# Altium schematic sheet PFM_SDCard.SchDoc(PFM_SDCard)
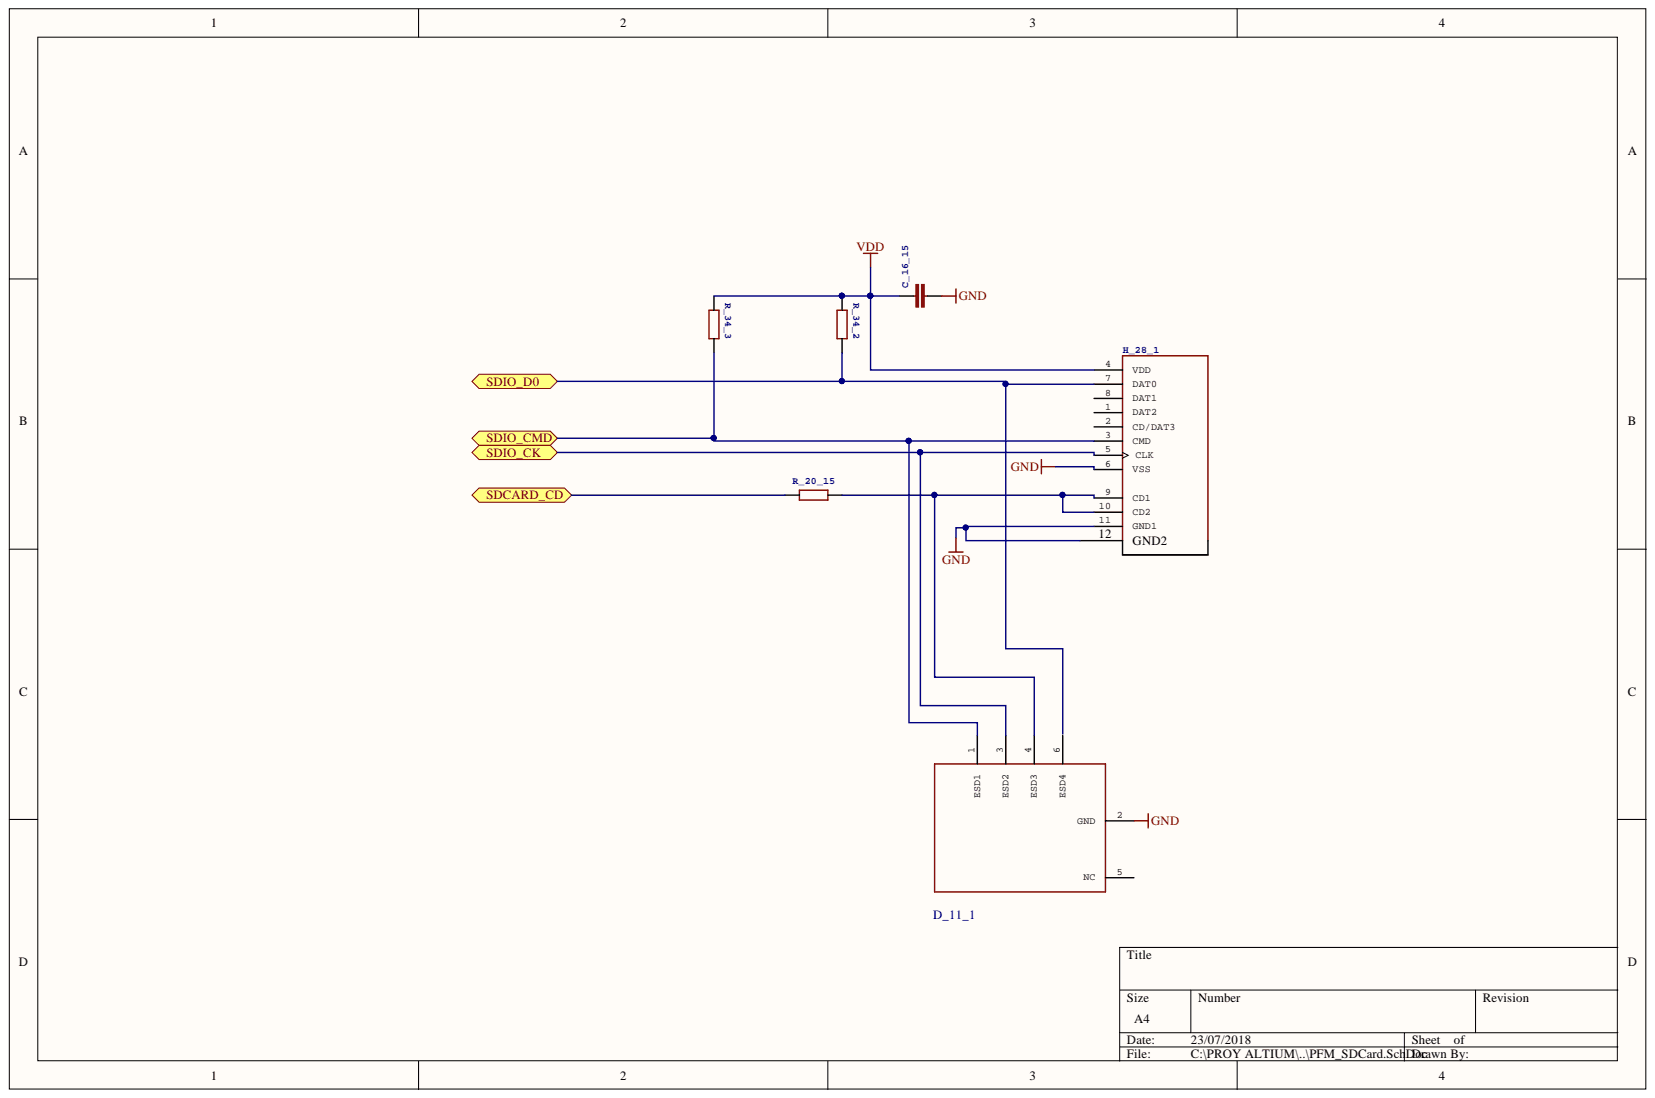
[diagram p0: PFM_SDCard.SchDoc]

## Parts
C_16_15  100nF;CL10B104KB8NNNC;Samsung;  [C0603K]  (value not drawn)
D_11_1  PUSB2X4YH;Nexperia;  [PUSB2X4Y_BRD]  (value not drawn)
H_28_1  MEM2061-01-188-00-A;Global Connector;  [GCT_MEM2061-01-188-00-A_REVA]  (value not drawn)
R_20_15  0;ERJ3GEY0R00V;Panasonic;  [R0603]  (value not drawn)
R_34_2  47K;RC0603JR-0747KL;Yageo;  [R0603]  (value not drawn)
R_34_3  47K;RC0603JR-0747KL;Yageo;  [R0603]  (value not drawn)

## Ports
SDCARD_CD, SDIO_CK, SDIO_CMD, SDIO_D0

## Nets
GND: C_16_15.2 D_11_1.2(GND) H_28_1.6(VSS) H_28_1.11(GND1) H_28_1.12(GND2)
VDD: C_16_15.1 H_28_1.4(VDD) R_34_2.1 R_34_3.1
SDCARD_CD: R_20_15.1 ; port SDCARD_CD
SDIO_CK: D_11_1.3(ESD2) H_28_1.5(CLK) ; port SDIO_CK
SDIO_CMD: D_11_1.1(ESD1) H_28_1.3(CMD) R_34_3.2 ; port SDIO_CMD
SDIO_D0: H_28_1.7(DAT0) R_34_2.2 ; port SDIO_D0
NetD_11_1_4: D_11_1.4(ESD3) H_28_1.9(CD1) H_28_1.10(CD2) R_20_15.2

Not connected: D_11_1 5(NC) 6(ESD4); H_28_1 1(DAT2) 2(CD/DAT3) 8(DAT1)

## Library data of the parts
C_16_15 = C-EUC0603K: CAPACITOR, European symbol; Library Name: rcl.IntLib; Component Kind: Standard
D_11_1 = PUSB2X4Y_ENL: Library Name: ESD.IntLib; Component Kind: Standard
H_28_1 = MEM2061-01-188-00-A_REVA: MF: Global Connector Technology; PRICE: None; PACKAGE: None; MP: MEM2061-01-188-00-A; Component Kind: Standard; AVAILABILITY: Unavailable; Library Name: Easynet_Commboard_v1p1.SCHLIB
R_20_15 R_34_2 R_34_3 = R-EU_R0603: RESISTOR, European symbol; Library Name: PBv4p0.SCHLIB; Component Kind: Standard
